# Altium schematic sheet WL_IO002_V0.1_1.SchDoc
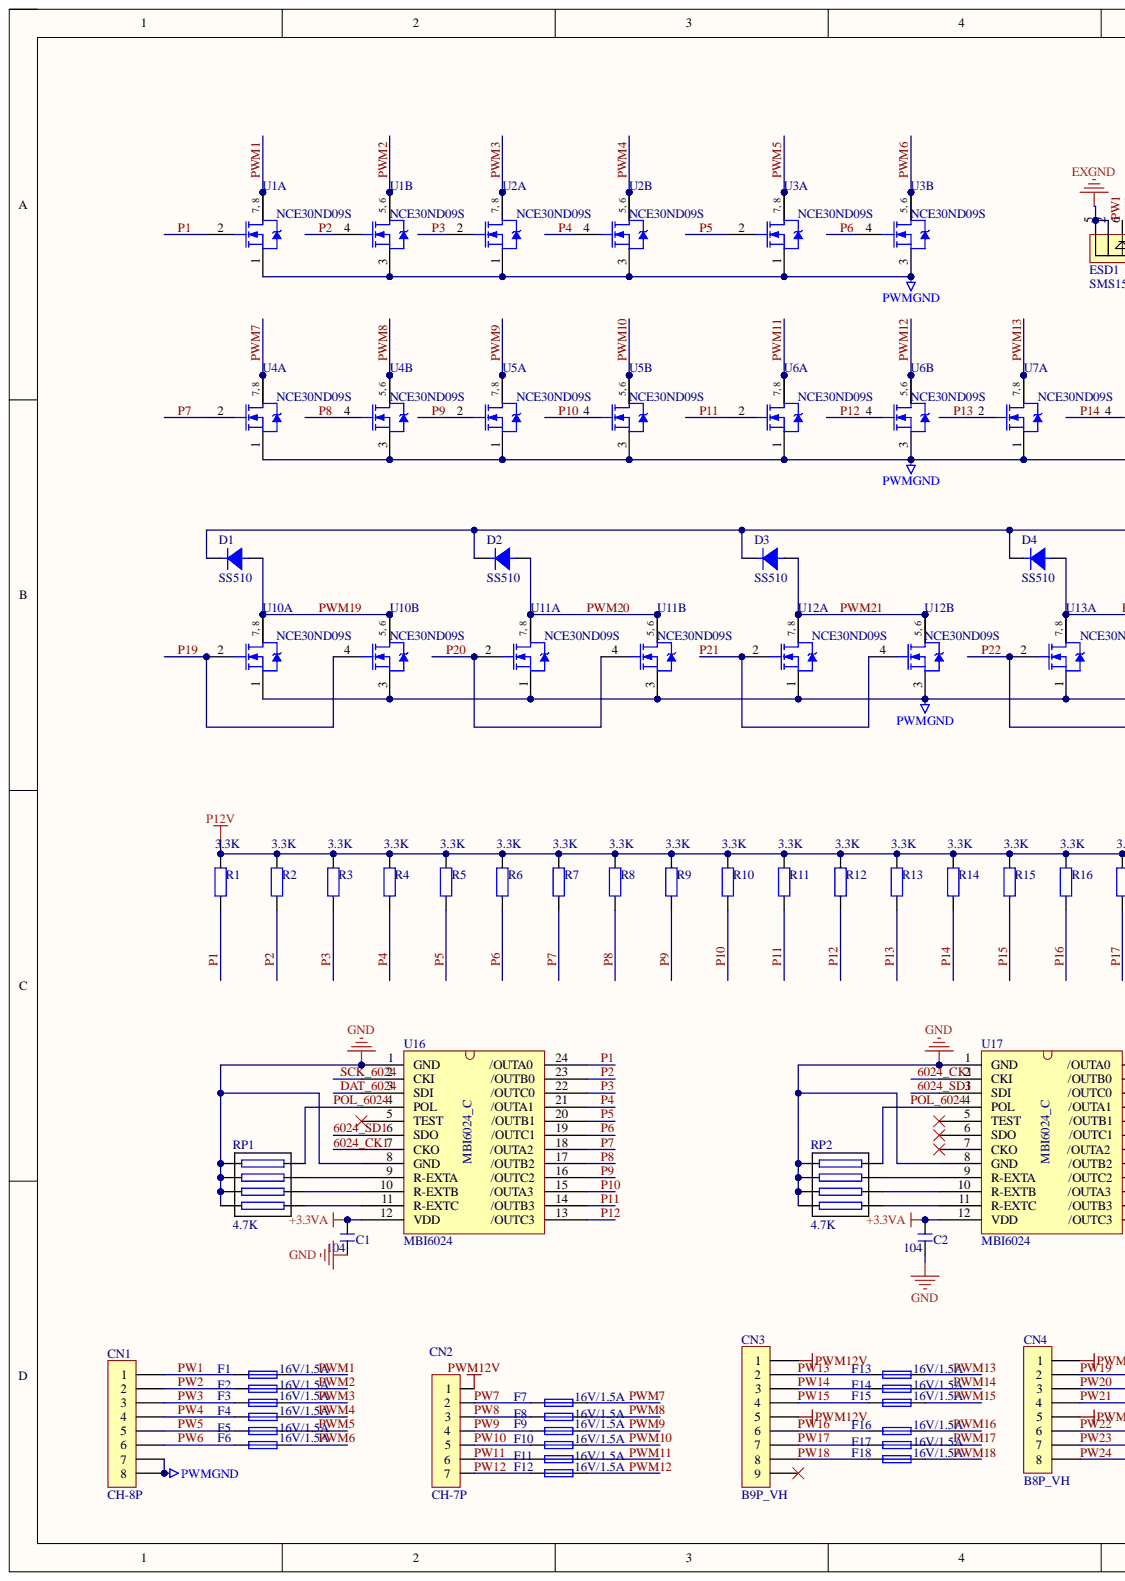
[diagram p0: WL_IO002_V0.1_1.SchDoc, left]
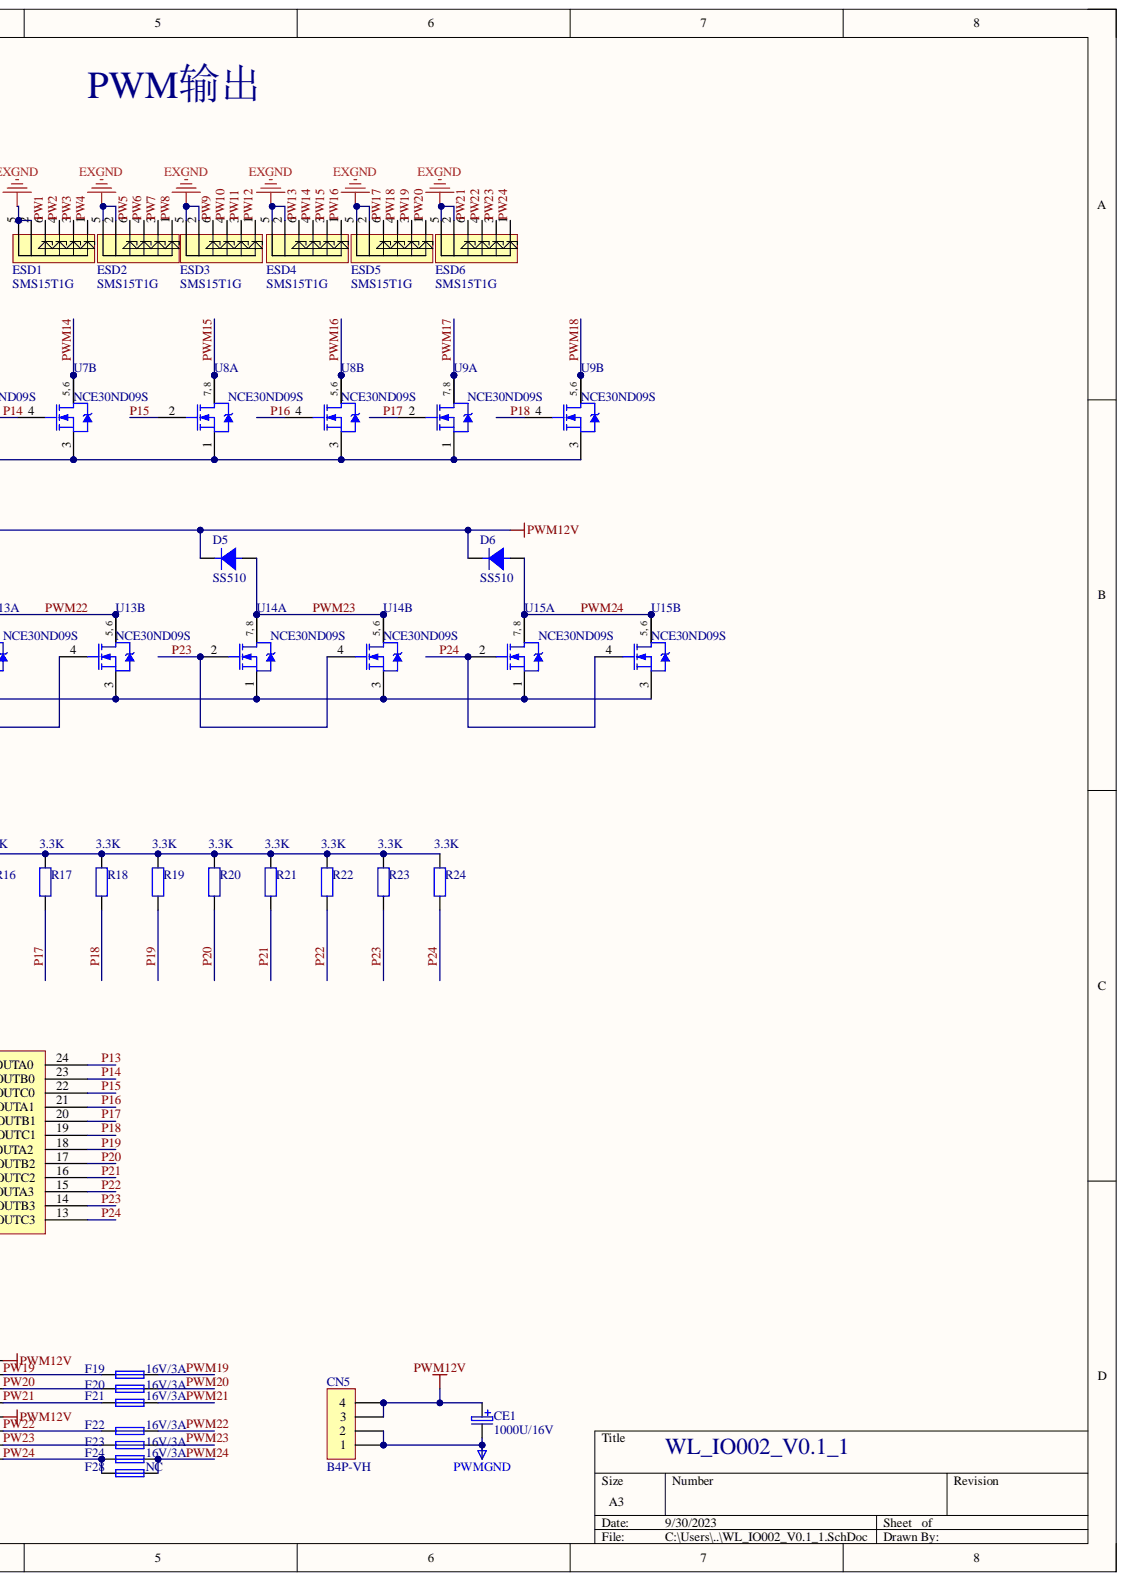
[diagram p1: WL_IO002_V0.1_1.SchDoc, right]

## Parts
C1  104  [C0805]
C2  104  [C0805]
CE1  1000U/16V  [C10X10.2SMT]
CN1  CH-8P  [CH-8P 3.96]
CN2  CH-7P  [CH-7P  3.96]
CN3  B9P_VH  [B9P-VH]
CN4  B8P_VH  [B8P-VH]
CN5  B4P-VH  [B4P-VH]
D1  SS510  [SMC]
D2  SS510  [SMC]
D3  SS510  [SMC]
D4  SS510  [SMC]
D5  SS510  [SMC]
D6  SS510  [SMC]
ESD1  SMS15T1G  [SOT457/SC-74]
ESD2  SMS15T1G  [SOT457/SC-74]
ESD3  SMS15T1G  [SOT457/SC-74]
ESD4  SMS15T1G  [SOT457/SC-74]
ESD5  SMS15T1G  [SOT457/SC-74]
ESD6  SMS15T1G  [SOT457/SC-74]
F1  16V/1.5A  [1812]
F2  16V/1.5A  [1812]
F3  16V/1.5A  [1812]
F4  16V/1.5A  [1812]
F5  16V/1.5A  [1812]
F6  16V/1.5A  [1812]
F7  16V/1.5A  [1812]
F8  16V/1.5A  [1812]
F9  16V/1.5A  [1812]
F10  16V/1.5A  [1812]
F11  16V/1.5A  [1812]
F12  16V/1.5A  [1812]
F13  16V/1.5A  [1812]
F14  16V/1.5A  [1812]
F15  16V/1.5A  [1812]
F16  16V/1.5A  [1812]
F17  16V/1.5A  [1812]
F18  16V/1.5A  [1812]
F19  16V/3A  [2920]
F20  16V/3A  [2920]
F21  16V/3A  [2920]
F22  16V/3A  [2920]
F23  16V/3A  [2920]
F24  16V/3A  [2920]
F28  NC  [1812]
R1  3.3K  [0805]
R2  3.3K  [0805]
R3  3.3K  [0805]
R4  3.3K  [0805]
R5  3.3K  [0805]
R6  3.3K  [0805]
R7  3.3K  [0805]
R8  3.3K  [0805]
R9  3.3K  [0805]
R10  3.3K  [0805]
R11  3.3K  [0805]
R12  3.3K  [0805]
R13  3.3K  [0805]
R14  3.3K  [0805]
R15  3.3K  [0805]
R16  3.3K  [0805]
R17  3.3K  [0805]
R18  3.3K  [0805]
R19  3.3K  [0805]
R20  3.3K  [0805]
R21  3.3K  [0805]
R22  3.3K  [0805]
R23  3.3K  [0805]
R24  3.3K  [0805]
RP1  4.7K  [RCML08]
RP2  4.7K  [RCML08]
U1  NCE30ND09S  [SOP-3.8-8]  (parts A,B)
U2  NCE30ND09S  [SOP-3.8-8]  (parts A,B)
U3  NCE30ND09S  [SOP-3.8-8]  (parts A,B)
U4  NCE30ND09S  [SOP-3.8-8]  (parts A,B)
U5  NCE30ND09S  [SOP-3.8-8]  (parts A,B)
U6  NCE30ND09S  [SOP-3.8-8]  (parts A,B)
U7  NCE30ND09S  [SOP-3.8-8]  (parts A,B)
U8  NCE30ND09S  [SOP-3.8-8]  (parts A,B)
U9  NCE30ND09S  [SOP-3.8-8]  (parts A,B)
U10  NCE30ND09S  [SOP-3.8-8]  (parts A,B)
U11  NCE30ND09S  [SOP-3.8-8]  (parts A,B)
U12  NCE30ND09S  [SOP-3.8-8]  (parts A,B)
U13  NCE30ND09S  [SOP-3.8-8]  (parts A,B)
U14  NCE30ND09S  [SOP-3.8-8]  (parts A,B)
U15  NCE30ND09S  [SOP-3.8-8]  (parts A,B)
U16  MBI6024  [SSOP-24]
U17  MBI6024  [SSOP-24]

## Nets
+3.3VA: C1.2 C2.2 U16.12(VDD) U17.12(VDD)
EXGND: ESD1.2 ESD1.5 ESD2.2 ESD2.5 ESD3.2 ESD3.5 ESD4.2 ESD4.5 ESD5.2 ESD5.5 ESD6.2 ESD6.5
GND: C1.1 C2.1 RP1.1 RP1.2 RP1.3 RP1.4 RP2.1 RP2.2 RP2.3 RP2.4 U16.1(GND) U16.8(GND) U17.1(GND) U17.8(GND)
P12V: R1.2 R2.2 R3.2 R4.2 R5.2 R6.2 R7.2 R8.2 R9.2 R10.2 R11.2 R12.2 R13.2 R14.2 R15.2 R16.2 R17.2 R18.2 R19.2 R20.2 R21.2 R22.2 R23.2 R24.2
PWM12V: CE1.1 CN2.1 CN3.1 CN3.5 CN4.1 CN4.5 CN5.3 CN5.4 D1.2 D2.2 D3.2 D4.2 D5.2 D6.2
PWMGND: CE1.2 CN1.7 CN1.8 CN5.1 CN5.2 U1.1 U1.3 U2.1 U2.3 U3.1 U3.3 U4.1 U4.3 U5.1 U5.3 U6.1 U6.3 U7.1 U7.3 U8.1 U8.3 U9.1 U9.3 U10.1 U10.3 U11.1 U11.3 U12.1 U12.3 U13.1 U13.3 U14.1 U14.3 U15.1 U15.3
6024_CK1: U16.7(CKO) U17.2(CKI)
6024_SD1: U16.6(SDO) U17.3(SDI)
DAT_6024: U16.3(SDI)
P1: R1.1 U1.2 U16.24(/OUTA0)
P2: R2.1 U1.4 U16.23(/OUTB0)
P3: R3.1 U2.2 U16.22(/OUTC0)
P4: R4.1 U2.4 U16.21(/OUTA1)
P5: R5.1 U3.2 U16.20(/OUTB1)
P6: R6.1 U3.4 U16.19(/OUTC1)
P7: R7.1 U4.2 U16.18(/OUTA2)
P8: R8.1 U4.4 U16.17(/OUTB2)
P9: R9.1 U5.2 U16.16(/OUTC2)
P10: R10.1 U5.4 U16.15(/OUTA3)
P11: R11.1 U6.2 U16.14(/OUTB3)
P12: R12.1 U6.4 U16.13(/OUTC3)
P13: R13.1 U7.2 U17.24(/OUTA0)
P14: R14.1 U7.4 U17.23(/OUTB0)
P15: R15.1 U8.2 U17.22(/OUTC0)
P16: R16.1 U8.4 U17.21(/OUTA1)
P17: R17.1 U9.2 U17.20(/OUTB1)
P18: R18.1 U9.4 U17.19(/OUTC1)
P19: R19.1 U10.2 U10.4 U17.18(/OUTA2)
P20: R20.1 U11.2 U11.4 U17.17(/OUTB2)
P21: R21.1 U12.2 U12.4 U17.16(/OUTC2)
P22: R22.1 U13.2 U13.4 U17.15(/OUTA3)
P23: R23.1 U14.2 U14.4 U17.14(/OUTB3)
P24: R24.1 U15.2 U15.4 U17.13(/OUTC3)
POL_6024: RP1.8 RP2.8 U16.4(POL) U17.4(POL)
PW1: CN1.1 ESD1.6 F1.1
PW2: CN1.2 ESD1.4 F2.1
PW3: CN1.3 ESD1.3 F3.1
PW4: CN1.4 ESD1.1 F4.1
PW5: CN1.5 ESD2.6 F5.1
PW6: CN1.6 ESD2.4 F6.1
PW7: CN2.2 ESD2.3 F7.1
PW8: CN2.3 ESD2.1 F8.1
PW9: CN2.4 ESD3.6 F9.1
PW10: CN2.5 ESD3.4 F10.1
PW11: CN2.6 ESD3.3 F11.1
PW12: CN2.7 ESD3.1 F12.1
PW13: CN3.2 ESD4.6 F13.1
PW14: CN3.3 ESD4.4 F14.1
PW15: CN3.4 ESD4.3 F15.1
PW16: CN3.6 ESD4.1 F16.1
PW17: CN3.7 ESD5.6 F17.1
PW18: CN3.8 ESD5.4 F18.1
PW19: CN4.2 ESD5.3 F19.1
PW20: CN4.3 ESD5.1 F20.1
PW21: CN4.4 ESD6.6 F21.1
PW22: CN4.6 ESD6.4 F22.1
PW23: CN4.7 ESD6.3 F23.1
PW24: CN4.8 ESD6.1 F24.1 F28.1
PWM1: F1.2 U1.7 U1.8
PWM2: F2.2 U1.5 U1.6
PWM3: F3.2 U2.7 U2.8
PWM4: F4.2 U2.5 U2.6
PWM5: F5.2 U3.7 U3.8
PWM6: F6.2 U3.5 U3.6
PWM7: F7.2 U4.7 U4.8
PWM8: F8.2 U4.5 U4.6
PWM9: F9.2 U5.7 U5.8
PWM10: F10.2 U5.5 U5.6
PWM11: F11.2 U6.7 U6.8
PWM12: F12.2 U6.5 U6.6
PWM13: F13.2 U7.7 U7.8
PWM14: F14.2 U7.5 U7.6
PWM15: F15.2 U8.7 U8.8
PWM16: F16.2 U8.5 U8.6
PWM17: F17.2 U9.7 U9.8
PWM18: F18.2 U9.5 U9.6
PWM19: D1.1 F19.2 U10.5 U10.6 U10.7 U10.8
PWM20: D2.1 F20.2 U11.5 U11.6 U11.7 U11.8
PWM21: D3.1 F21.2 U12.5 U12.6 U12.7 U12.8
PWM22: D4.1 F22.2 U13.5 U13.6 U13.7 U13.8
PWM23: D5.1 F23.2 U14.5 U14.6 U14.7 U14.8
PWM24: D6.1 F24.2 F28.2 U15.5 U15.6 U15.7 U15.8
SCK_6024: U16.2(CKI)
NetRP1_5: RP1.5 U16.11(R-EXTC)
NetRP1_6: RP1.6 U16.10(R-EXTB)
NetRP1_7: RP1.7 U16.9(R-EXTA)
NetRP2_5: RP2.5 U17.11(R-EXTC)
NetRP2_6: RP2.6 U17.10(R-EXTB)
NetRP2_7: RP2.7 U17.9(R-EXTA)

Not connected: CN3 9; U16 5(TEST); U17 5(TEST) 6(SDO) 7(CKO)

## Library data of the parts
C1 C2 = CAP: 贴片陶瓷电容; Component Kind: Standard; Library Name: RCL.SchLib
CE1 = CAP2: 电解电容; Component Kind: Standard; Library Name: RCL.SchLib
CN1 CN4 = 8PIN: Connector; Component Kind: Standard; Library Name: PIN.SchLib
CN2 = 7PIN: Connector; Component Kind: Standard; Library Name: PIN.SchLib
CN3 = 9PIN: Connector; Component Kind: Standard; Library Name: PIN.SchLib
CN5 = 4PIN: Connector; Component Kind: Standard; Library Name: PIN.SchLib
D1-D6 = D: 肖特基二极管; Component Kind: Standard; Library Name: D.SchLib
ESD1-ESD6 = PESD12VS4UD: ESD保护; Component Kind: Standard; Library Name: D.SchLib
F1-F24 F28 = FUSE: PTC可恢复保险丝; Component Kind: Standard; Library Name: other.SchLib
R1-R24 = Res2: Resistor; Component Kind: Standard; LatestRevisionDate: 17-Jul-2002; LatestRevisionNote: Re-released for DXP Platform.; Library Name: Miscellaneous Devices.IntLib; PackageReference: AXIAL-0.4; Published: 8-Jun-2000; Signal Integrity: Res; Simulation: RESISTOR; Value: 3.3K; Publisher: Altium Limited
RP1 RP2 = RCML08: 排阻; Component Kind: Standard; Library Name: RCL.SchLib
U1-U15 = IRF7313: N沟道场效应管; Component Kind: Standard; Library Name: Q.SchLib
U16 U17 = MBI6024_C: PWM LED driver; Component Kind: Standard; Library Name: IC.SchLib
